# Altium schematic sheet ESP32.SchDoc
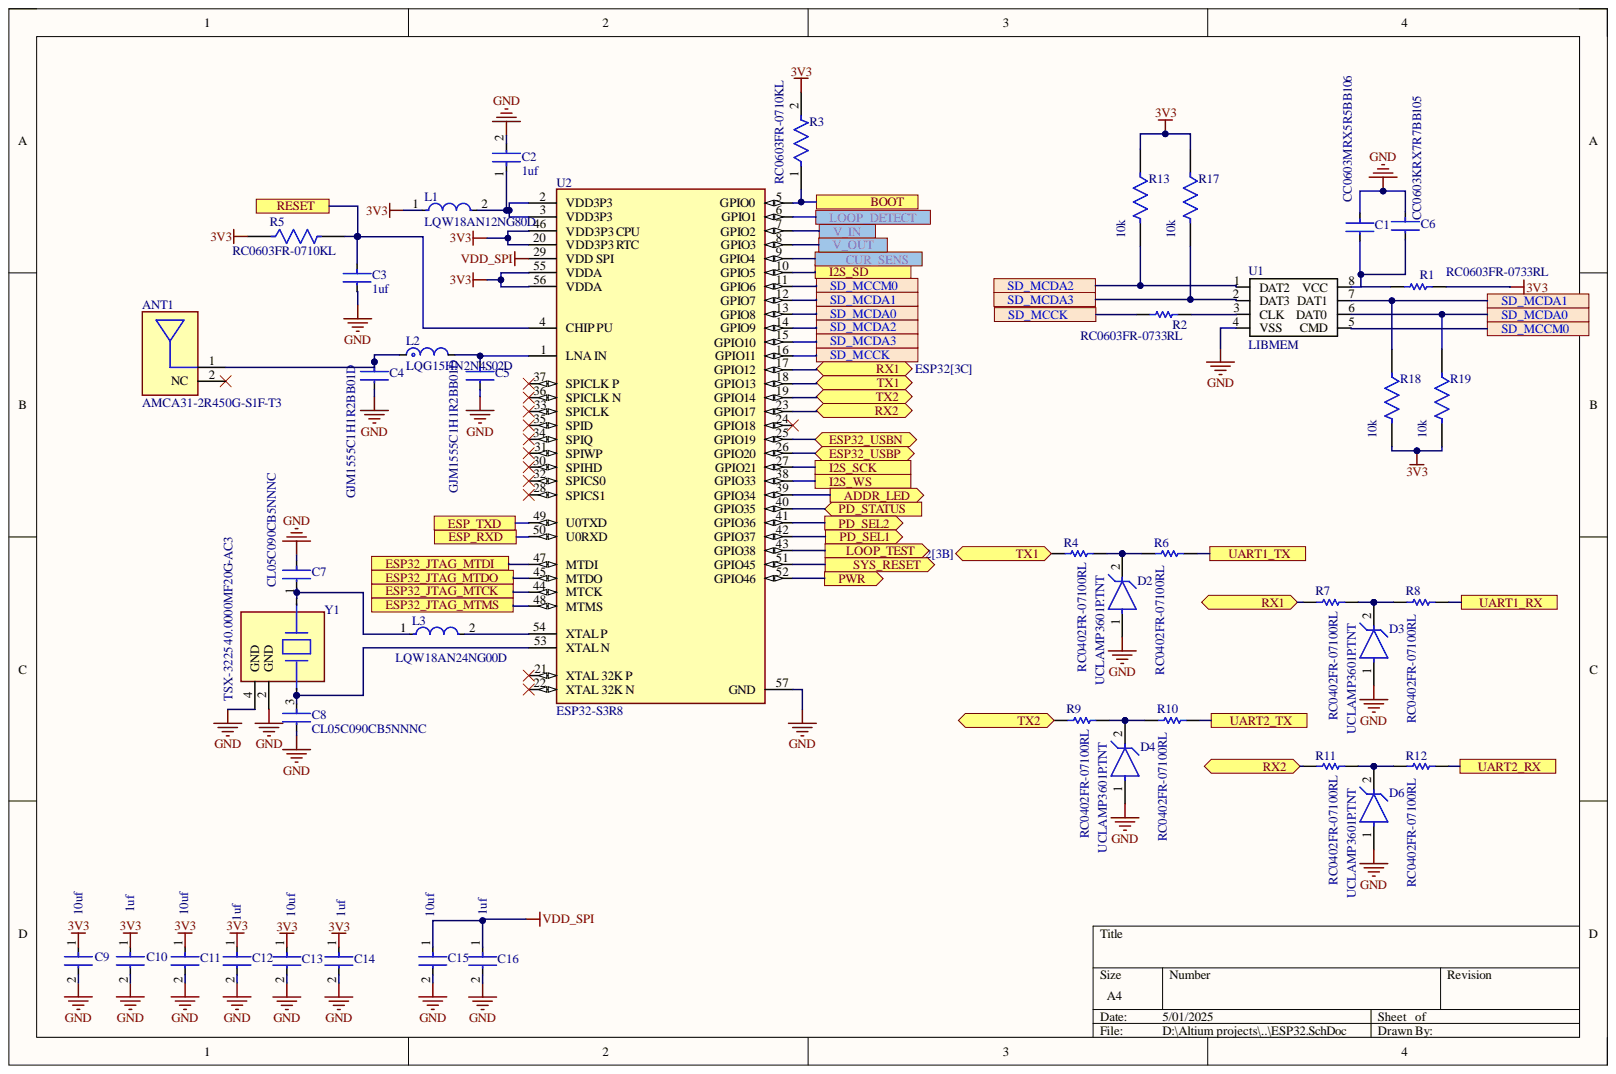
[diagram p0: ESP32.SchDoc]

## Parts
ANT1  AMCA31-2R450G-S1F-T3  [FP-AMCA31-MFG]
C1  CC0603MRX5R5BB106  [0603]
C2  1uf  [FP-0603-L_1_6_0_15-W_0_8-IPC_B]
C3  1uf  [FP-0603-L_1_6_0_15-W_0_8-IPC_B]
C4  GJM1555C1H1R2BB01D  [FP-GJM155-0_05-IPC_B]
C5  GJM1555C1H1R2BB01D  [FP-GJM155-0_05-IPC_B]
C6  CC0603KRX7R7BB105  [0603]
C7  CL05C090CB5NNNC  [FP-CL690-IPC_C]
C8  CL05C090CB5NNNC  [FP-CL690-IPC_C]
C9  10uf  [FP-0603-L_1_6_0_15-W_0_8-IPC_B]
C10  1uf  [FP-0603-L_1_6_0_15-W_0_8-IPC_B]
C11  10uf  [FP-0603-L_1_6_0_15-W_0_8-IPC_B]
C12  1uf  [FP-0603-L_1_6_0_15-W_0_8-IPC_B]
C13  10uf  [FP-0603-L_1_6_0_15-W_0_8-IPC_B]
C14  1uf  [FP-0603-L_1_6_0_15-W_0_8-IPC_B]
C15  10uf  [FP-0603-L_1_6_0_15-W_0_8-IPC_B]
C16  1uf  [FP-0603-L_1_6_0_15-W_0_8-IPC_B]
D2  UCLAMP3601P.TNT  [FP-SLP1006P2-MFG]
D3  UCLAMP3601P.TNT  [FP-SLP1006P2-MFG]
D4  UCLAMP3601P.TNT  [FP-SLP1006P2-MFG]
D6  UCLAMP3601P.TNT  [FP-SLP1006P2-MFG]
L1  LQW18AN12NG80D  [FP-LQW18AN_80-MFG]
L2  LQG15HN2N4S02D  [FP-LQG15HH_02-MFG]
L3  LQW18AN24NG00D  [FP-LQW18AN_00-MFG]
R1  RC0603FR-0733RL  [0603]
R2  RC0603FR-0733RL  [0603]
R3  RC0603FR-0710KL  [FP-RC0603-0_55-MFG]
R4  RC0402FR-07100RL  [0402_RES]
R5  RC0603FR-0710KL  [FP-RC0603-0_55-MFG]
R6  RC0402FR-07100RL  [0402_RES]
R7  RC0402FR-07100RL  [0402_RES]
R8  RC0402FR-07100RL  [0402_RES]
R9  RC0402FR-07100RL  [0402_RES]
R10  RC0402FR-07100RL  [0402_RES]
R11  RC0402FR-07100RL  [0402_RES]
R12  RC0402FR-07100RL  [0402_RES]
R13  10k  [FP-RC0603-0_55-MFG]
R17  10k  [FP-RC0603-0_55-MFG]
R18  10k  [FP-RC0603-0_55-MFG]
R19  10k  [FP-RC0603-0_55-MFG]
U1  LIBMEM  [LGA-8]
U2  ESP32-S3R8  [FP-ESP32-S3R8-IPC_A]
Y1  TSX-3225 40.0000MF20G-AC3  Series: TSX-3225  [FP-TSX-3225-MFG]

## Ports
ADDR_LED, BOOT, CUR_SENS, ESP32_JTAG_MTCK, ESP32_JTAG_MTDI, ESP32_JTAG_MTDO, ESP32_JTAG_MTMS, ESP32_USBN, ESP32_USBP, ESP_RXD, ESP_TXD, I2S_SCK, I2S_SD, I2S_WS, LOOP_DETECT, LOOP_TEST, PD_SEL1, PD_SEL2, PD_STATUS, PWR, RESET, RX1, RX2, SD_MCCM0, SD_MCDA0, SD_MCDA1, SD_MCDA2, SD_MCDA3, SD_MСCK, SYS_RESET, TX1, TX2, UART1_RX, UART1_TX, UART2_RX, UART2_TX, V_IN, V_OUT

## Nets
3V3: C9.1 C10.1 C11.1 C12.1 C13.1 C14.1 L1.1 R1.2 R3.2 R5.1 R13.1 R17.1 R18.2 R19.2 U2.20(VDD3P3_RTC) U2.46(VDD3P3_CPU) U2.55(VDDA) U2.56(VDDA)
GND: C1.1 C2.2 C3.2 C4.2 C5.2 C6.1 C7.1 C8.2 C9.2 C10.2 C11.2 C12.2 C13.2 C14.2 C15.2 C16.2 D2.1 D3.1 D4.1 D6.1 U1.4(VSS) U2.57(GND) Y1.2(GND) Y1.4(GND)
VDD_SPI: C15.1 C16.1 U2.29(VDD_SPI)
ADDR_LED: U2.39(GPIO34) ; port ADDR_LED
BOOT: R3.1 U2.5(GPIO0) ; port BOOT
CUR_SENS: U2.9(GPIO4) ; port CUR_SENS
ESP32_JTAG_MTCK: U2.44(MTCK) ; port ESP32_JTAG_MTCK
ESP32_JTAG_MTDI: U2.47(MTDI) ; port ESP32_JTAG_MTDI
ESP32_JTAG_MTDO: U2.45(MTDO) ; port ESP32_JTAG_MTDO
ESP32_JTAG_MTMS: U2.48(MTMS) ; port ESP32_JTAG_MTMS
ESP32_USBN: U2.25(GPIO19) ; port ESP32_USBN
ESP32_USBP: U2.26(GPIO20) ; port ESP32_USBP
ESP_RXD: U2.50(U0RXD) ; port ESP_RXD
ESP_TXD: U2.49(U0TXD) ; port ESP_TXD
I2S_SCK: U2.27(GPIO21) ; port I2S_SCK
I2S_SD: U2.10(GPIO5) ; port I2S_SD
I2S_WS: U2.38(GPIO33) ; port I2S_WS
LOOP_DETECT: U2.6(GPIO1) ; port LOOP_DETECT
LOOP_TEST: U2.43(GPIO38) ; port LOOP_TEST
PD_SEL1: U2.42(GPIO37) ; port PD_SEL1
PD_SEL2: U2.41(GPIO36) ; port PD_SEL2
PD_STATUS: U2.40(GPIO35) ; port PD_STATUS
PWR: U2.52(GPIO46) ; port PWR
RESET: C3.1 R5.2 U2.4(CHIP_PU) ; port RESET
RX1: R7.1 U2.17(GPIO12) ; port RX1
RX2: R11.1 U2.23(GPIO17) ; port RX2
SD_MCCM0: U1.5(CMD) U2.11(GPIO6) ; port SD_MCCM0
SD_MCDA0: R19.1 U1.6(DAT0) U2.13(GPIO8) ; port SD_MCDA0
SD_MCDA1: R18.1 U1.7(DAT1) U2.12(GPIO7) ; port SD_MCDA1
SD_MCDA2: R13.2 U1.1(DAT2) U2.14(GPIO9) ; port SD_MCDA2
SD_MCDA3: R17.2 U1.2(DAT3) U2.15(GPIO10) ; port SD_MCDA3
SD_MСCK: R2.1 U2.16(GPIO11) ; port SD_MСCK
SYS_RESET: U2.51(GPIO45) ; port SYS_RESET
TX1: R4.1 U2.18(GPIO13) ; port TX1
TX2: R9.1 U2.19(GPIO14) ; port TX2
UART1_RX: R8.2 ; port UART1_RX
UART1_TX: R6.2 ; port UART1_TX
UART2_RX: R12.2 ; port UART2_RX
UART2_TX: R10.2 ; port UART2_TX
V_IN: U2.7(GPIO2) ; port V_IN
V_OUT: U2.8(GPIO3) ; port V_OUT
NetANT1_1: ANT1.1 C4.1 L2.1
NetC1_2: C1.2 C6.2 R1.1 U1.8(VCC)
NetC2_1: C2.1 L1.2 U2.2(VDD3P3) U2.3(VDD3P3)
NetC5_1: C5.1 L2.2 U2.1(LNA_IN)
NetC7_2: C7.2 L3.1 Y1.1
NetC8_1: C8.1 U2.53(XTAL_N) Y1.3
NetD2_2: D2.2 R4.2 R6.1
NetD3_2: D3.2 R7.2 R8.1
NetD4_2: D4.2 R9.2 R10.1
NetD6_2: D6.2 R11.2 R12.1
NetL3_2: L3.2 U2.54(XTAL_P)
NetR2_2: R2.2 U1.3(CLK)

Not connected: ANT1 2; U2 21(XTAL_32K_P) 22(XTAL_32K_N) 24(GPIO18) 28(SPICS1) 30(SPIHD) 31(SPIWP) 32(SPICS0) 33(SPICLK) 34(SPIQ) 35(SPID) 36(SPICLK_N) 37(SPICLK_P)

## Library data of the parts
ANT1 = CMP-27836-000002-1: RF ANT 2.4GHZ CHIP SOLDER SMD; Manufacturer: Abracon; Bandwidth: 100MHz; Impedance: 50Ω; Max Frequency: 2450MHz; Number Of Bands: 1; Power Rating: 3W; Automotive: No; Category: Ant; Component Kind: Standard; ComponentLink2Description: Datasheet; ComponentLink2URL: https://abracon.com/chip-antenna/AMCA31-2R450G-S1F-T3.pdf; Device Class L1: Integrated Circuits (ICs); Device Class L2: RF Semiconductors and Devices; Device Class L3: RF Antennas; Digikey Description: RF ANT 2.4GHZ CHIP SOLDER SMD; Height: 1.4mm; Lead Free: Yes; Library Name: Altium Content Vault; Manufacturer Part Number: AMCA31-2R450G-S1F-T3; Mouser Description: Antennas 2.45GHz BW 90dB + Gain -1 dBi avg.; Octopart: https://octopart.com/amca31-2r450g-s1f-t3-abracon-31435383; Package: AMCA31; RoHS: TRUE; Temperature: -40°C to +85°C; Temperature Range High: +85°C; Temperature Range Low: -40°C
C1 = e9c51b7dd13d4faca003ae77663ab08: CAP CER 10UF 6.3V X5R 0603; Dissipation Factor: 10%; Tolerance: 20%; Capacitance: 10uF; Case Code (Imperial): 0603; Case Code (Metric): 1608; Case/Package: 0603; Component Kind: Standard; Construction: Flat; Depth: 0.8mm; Dielectric: X5R; Halogen Free: Halogen Free; Height: 0.8mm; Lead Free: Lead Free; Length: 1.6mm; Library Name: Schlib1.SchLib; Max Operating Temperature: 85°C; Min Operating Temperature: -55°C; Mount: Surface Mount; Number of Pins: 2; Package Quantity: 4000; Packaging: Tape and Reel; Radiation Hardening: No; REACH SVHC: No SVHC; Resistor Type: Ceramic; RoHS Compliant: Yes; Series: CC; Termination: SMD/SMT; Thickness: 0.039inch; Voltage Rating: 6.3V; Voltage Rating (DC): 6.3V; Width: 0.031inch
C2 C3 C10 C14 C16 = CMP-2000-07531-4: Ceramic Capacitor General Use 1uF ±10% 50V X7R 0603; Capacitance: 1uF; Case Code (Imperial): 0603; Case Code (Metric): 1608; Case/Package: 0603; Component Kind: Standard; Construction: Flat; Depth: 0.8mm; Dielectric: X7R; Halogen Free: Halogen Free; Height: 0.8mm; Length: 1.6mm; Library Name: Altium Content Vault; Max Operating Temperature: 125°C; Min Operating Temperature: -55°C; Mount: Surface Mount; Package Quantity: 1; Packaging: Tape and Reel; Radiation Hardening: No; RoHS Compliant: Yes; Series: M; Termination: SMD/SMT; Thickness: 0.037inch; Tolerance: 10%; Voltage Rating: 50V; Voltage Rating (DC): 50V; Width: 0.031inch
C4 C5 = CMP-2008-03813-2: Multi-Layer Ceramic Capacitor 1.2pF C0G  ±0.1pF 0402 Paper T/R; Temperature Coefficient: 30ppm/°C; Voltage: 50V; Capacitance: 1.2pF; Case Code (Imperial): 0402; Case Code (Metric): 1005; Case/Package: 0402; Component Kind: Standard; Depth: 0.5mm; Dielectric: C0G; Height: 0.5mm; Lead Free: Lead Free; Lead Pitch: 0.3mm; Length: 1mm; Library Name: Altium Content Vault; Max Operating Temperature: 125°C; Min Operating Temperature: -55°C; Mount: Surface Mount; Number of Pins: 2; Package Quantity: 10000; Packaging: Tape and Reel; Radiation Hardening: No; REACH SVHC: No SVHC; Termination: SMD/SMT; Thickness: 0.022inch; Voltage Rating: 50V; Voltage Rating (DC): 50V; Weight: 2.3E-05oz; Width: 0.5mm
C6 = 11868f565c42a9108669ba33697ecf6: CAP CER 1UF 16V X7R 0603; Manufacturer: YAGEO; Material: Ceramic; Temperature Coefficient: 15%; Tolerance: 0.1; Automotive: No; Capacitance: 1 uF; Category: Cap; Component Kind: Standard; ComponentLink2Description: Datasheet; ComponentLink2URL: http://www.yageo.com/documents/recent/UPY-GPHC_X7R_6.3V-to-50V_18.pdf; Device Class L1: Passive Components; Device Class L2: Capacitors; Device Class L3: Ceramic Capacitors; Digikey Description: CAP CER 1UF 16V X7R 0603; Footprint Url: http://www.yageo.com/exep/pages/download/literatures/UPY-C_GEN_24.pdf; Height: 0.9mm; Lead Free: Yes; Library Name: Schlib1.SchLib; Manufacturer Part Number: CC0603KRX7R7BB105; Mouser Description: Multilayer Ceramic Capacitor, CC Series, 1 - F, - 10%, X7R, 16 V, 0603 [1608 Metric]; Octopart: https://octopart.com/cc0603krx7r7bb105-yageo-42271928; Package: CC0603-DA; RoHS: TRUE; Temperature: -55°C to +125°C; Temperature Characteristic: X7R; Temperature Range High: +125°C; Temperature Range Low: -55°C; Value: 1 uF; Voltage: 16 V; Voltage Rating: 16 V
C7 C8 = CMP-13271-001704-1: Cap Ceramic 9pF 50V C0G ±0.25pF SMD 0402 +125°C Paper T/R; Capacitance: 9pF; Case Code (Imperial): 0402; Case Code (Metric): 1005; Case/Package: 0402; Component Kind: Standard; Depth: 0.5mm; Dielectric: C0G; Height: 0.5mm; Length: 1mm; Library Name: Altium Content Vault; Max Operating Temperature: 125°C; Min Operating Temperature: -55°C; Mount: Surface Mount; Package Quantity: 1; Packaging: Tape and Reel; Radiation Hardening: No; Thickness: 0.022inch; Voltage Rating: 50V; Voltage Rating (DC): 50V; Width: 0.02inch
C9 C11 C13 C15 = CMP-2000-07531-4: Ceramic Capacitor General Use 10uF ±10% 50V X7R 0603; Capacitance: 1uF; Case Code (Imperial): 0603; Case Code (Metric): 1608; Case/Package: 0603; Component Kind: Standard; Construction: Flat; Depth: 0.8mm; Dielectric: X7R; Halogen Free: Halogen Free; Height: 0.8mm; Length: 1.6mm; Library Name: Altium Content Vault; Max Operating Temperature: 125°C; Min Operating Temperature: -55°C; Mount: Surface Mount; Package Quantity: 1; Packaging: Tape and Reel; Radiation Hardening: No; RoHS Compliant: Yes; Series: M; Termination: SMD/SMT; Thickness: 0.037inch; Tolerance: 10%; Voltage Rating: 50V; Voltage Rating (DC): 50V; Width: 0.031inch
C12 = CMP-2000-07531-4: Ceramic Capacitor General Use 10uF ±10% 50V X7R 0603; Capacitance: 1uF; Case Code (Imperial): 0603; Case Code (Metric): 1608; Case/Package: 0603; Component Kind: Standard; Construction: Flat; Depth: 0.8mm; Dielectric: X7R; Halogen Free: Halogen Free; Height: 0.8mm; Length: 1.6mm; Library Name: Altium Content Vault; Max Operating Temperature: 125°C; Min Operating Temperature: -55°C; Mount: Surface Mount; Package Quantity: 1; Packaging: Tape and Reel; Radiation Hardening: No; RoHS Compliant: Yes; Series: M; Termination: SMD/SMT; Thickness: 0.037inch; Tolerance: 10%; Voltage Rating: 50V; Voltage Rating (DC): 50V; Width: 0.031inch
D2-D4 D6 = CMP-56445-000005-1: TVS DIODE 33VWM 60VC SLP1006P2; Clamping Voltage: 60V; Diode Type: ZENER; Direction: Unidirectional; Element Configuration: Single; Leakage Current: 1uA; Max Breakdown Voltage: 45V; Min Breakdown Voltage: 36V; Number of Unidirectional Channels: 1; Peak Pulse Current: 2A; Peak Pulse Power: 125W; Power Dissipation: 170W; Power Line Protection: No; Reverse Standoff Voltage: 33V; Case Code (Imperial): 0402; Case Code (Metric): 1006; Case/Package: SMD/SMT; Clamping Voltage-Max: 60V; Component Kind: Standard; Depth: 0.6mm; Lead Free: Lead Free; Length: 1mm; Library Name: Altium Content Vault; Max Operating Temperature: 125°C; Min Operating Temperature: -40°C; Mount: Surface Mount; Number of Pins: 2; Packaging: Tape and Reel; Radiation Hardening: No; Resistor Type: Zener; RoHS Compliant: Yes; Series: Automotive, AEC-Q100, uClamp®; Working Voltage: 33V
L1 = CMP-06042-005156-1: Wire Wound RF Inductor 12nH ±2% 1500mA 0.064Ω 0603 (1608); Core Material: Ferrite; Current Rating: 1.5A; DC Resistance (DCR): 64mR; Q Factor: 37; Self Resonant Frequency: 5GHz; Shielding: Unshielded; Test Frequency: 100MHz; Case Code (Imperial): 0603; Case Code (Metric): 1608; Case/Package: 1608; Component Kind: Standard; Height: 0.9mm; Height - Seated (Max): 0.039inch; Inductance: 12nH; Length: 0.065inch; Library Name: Altium Content Vault; Max DC Current: 1.5A; Max Operating Temperature: 125°C; Min Operating Temperature: -55°C; Mount: Surface Mount; Package Quantity: 4000; Packaging: Tape and Reel; Resistor Type: Wirewound; Termination: SMD/SMT; Tolerance: 2%; Width: 0.8mm
L2 = CMP-06042-001992-1: Multilayer type RF Inductor 2.4nH ±0.3nH 0.1Ω 800mA 0402; Core Material: Air; Current: 300mA; DC Resistance (DCR): 160mR; Q Factor: 8; Self Resonant Frequency: 6GHz; Shielding: Unshielded; Test Frequency: 100MHz; Tolerance: 5%; Case Code (Imperial): 0402; Case Code (Metric): 1005; Case/Package: 0402; Component Kind: Standard; Current Rating: 300mA; DC Current: 300mA; Depth: 0.55mm; Height: 0.5mm; Height - Seated (Max): 0.022inch; Inductance: 2.4nH; Lead Free: Lead Free; Length: 1mm; Library Name: Altium Content Vault; Max DC Current: 300mA; Max Operating Temperature: 125°C; Military Standard: Not; Min Operating Temperature: -55°C; Mount: Surface Mount; Number of Pins: 2; Package Quantity: 10000; Packaging: Tape and Reel; Radiation Hardening: No; REACH SVHC: No SVHC; Termination: SMD/SMT; Width: 0.5mm
L3 = CMP-06042-014248-1: Wire Wound RF Inductor 24nH ±2% 500mA 0.21Ω 0603 (1608); Core Material: Non-Magnetic; Current: 850mA; DC Resistance (DCR): 210mR; Q Factor: 40; Self Resonant Frequency: 3.8GHz; Shielding: Unshielded; Test Frequency: 100MHz; Case Code (Imperial): 0603; Case Code (Metric): 1608; Case/Package: 0603; Component Kind: Standard; Current Rating: 500mA; DC Current: 500mA; Depth: 1mm; Height: 0.8mm; Height - Seated (Max): 0.039inch; Inductance: 24nH; Inductance Tolerance: 2%; Lead Free: Lead Free; Length: 1.6mm; Library Name: Altium Content Vault; Max DC Current: 500mA; Max Operating Temperature: 125°C; Military Standard: Not; Min Operating Temperature: -55°C; Mount: Surface Mount; Package Quantity: 1; Packaging: Tape and Reel; Radiation Hardening: No; REACH SVHC: No SVHC; Resistor Type: Wirewound; Termination: SMD/SMT; Tolerance: 2%; Weight: 0.000106oz; Width: 0.031inch
R1 R2 = RES-2: Chip Resistor, 33 Ohm, +/- 1%, 0.1 W, -55 to 155 degC, 0603 (1608 Metric), RoHS, Tape and Reel; Brand: Yageo; Power Rating: 100mW; Resistance: 33Ohms; Temperature Coefficient: 100PPM/C; Unit Weight: 0.000071oz; Voltage Rating: 75V; Case Code - in: 0603; Case Code - mm: 1608; Component Kind: Standard; Datasheet URL: http://www.yageo.com/documents/recent/PYu-RC_Group_51_RoHS_L_7.pdf; Datasheet Version: Rev. 7, 05/2017; Height: 0.45mm; Length: 1.6mm; Library Name: Schlib1.SchLib; Manufacturer: Yageo; Manufacturer URL: http://www.yageo.com/; Mounting Technology: SurfaceMount; Operating Temperature Range: -55Cto+155C; Package / Case: 0603(1608metric); Package Description: 2-Pin Surface Mount Device, Body 1.6 x 0.8 mm; Package Reference: 0603; Packaging: Reel; Product: ThickFilmResistorsGeneralPurpose; Product Category: ThickFilmResistors; RoHS: True; Series: RC; Termination Style: SMD/SMT; Tolerance: 1%; Type: GeneralPurposeChipResistorSurfaceMount; Width: 0.8mm
R3 R5 R13 R17-R19 = CMP-2100-03664-2: RES 10K OHM 1% 1/10W 0603; Max Power Dissipation: 100mW; Resistance: 10kR; Temperature Coefficient: 100ppm/°C; Voltage Rating: 75V; Voltage Rating (DC): 50V; Case Code (Imperial): 0603; Case Code (Metric): 1608; Case/Package: 0603; Component Kind: Standard; Construction: Rectangular; Depth: 0.8mm; Features: Moisture Resistant; Height: 0.45mm; Lead Free: Lead Free; Lead Pitch: 0.3mm; Length: 1.6mm; Library Name: Altium Content Vault; Max Operating Temperature: 155°C; Military Standard: Not; Min Operating Temperature: -55°C; Number of Pins: 2; Number of Terminations: 2; Packaging: Tape and Reel; Power Rating: 100mW; Resistance Tolerance: 1%; Resistor Type: Thick Film; RoHS Compliant: Yes; Series: RC; Size Code: 0603; Termination: SMD/SMT; Tolerance: 1%; Weight: 7.1E-05oz; Width: 0.8mm
R4 R6-R12 = CMP-2002-07033-1: Manufacturer: Yageo / Phycomp; Part Number: RC0402FR-07910RL; Component Kind: Standard; Library Name: Schlib2.SchLib
U2 = CMP-10700-118292493-1: ESP32-S3R8 RF System on a Chip - SoC SMD IC, Dual-Core MCU, Wi-Fi 2.4G BLE 5.0 Combo; Component Kind: Standard; Library Name: Altium Content Vault; NexarPartId: 118292493
Y1 = CMP-36405-000018-1: CRYSTAL 40.0000MHZ 9PF SMD; Drive Level: 200uW; ESR (Equivalent Series Resistance): 40R; Frequency: 24MHz; Frequency Stability: 20ppm; Frequency Tolerance: 10ppm; Case/Package: SMD/SMT; Component Kind: Standard; Depth: 2.5mm; Height: 0.024inch; Lead Free: Lead Free; Length: 3.2mm; Library Name: Altium Content Vault; Load Capacitance: 9pF; Max Operating Temperature: 105°C; Min Operating Temperature: -40°C; Mount: Surface Mount; Number of Pins: 4; Package Quantity: 250; Packaging: Tape and Reel; RoHS Compliant: Yes; Termination: SMD/SMT; Tolerance: 10ppm; Weight: 0.000564oz; Width: 2.5mm
U1 = LIBMEM: Component Kind: Standard; Library Name: Schlib2.SchLib
